# Altium schematic sheet IPC_Controller.SchDoc(IPC_Controller)
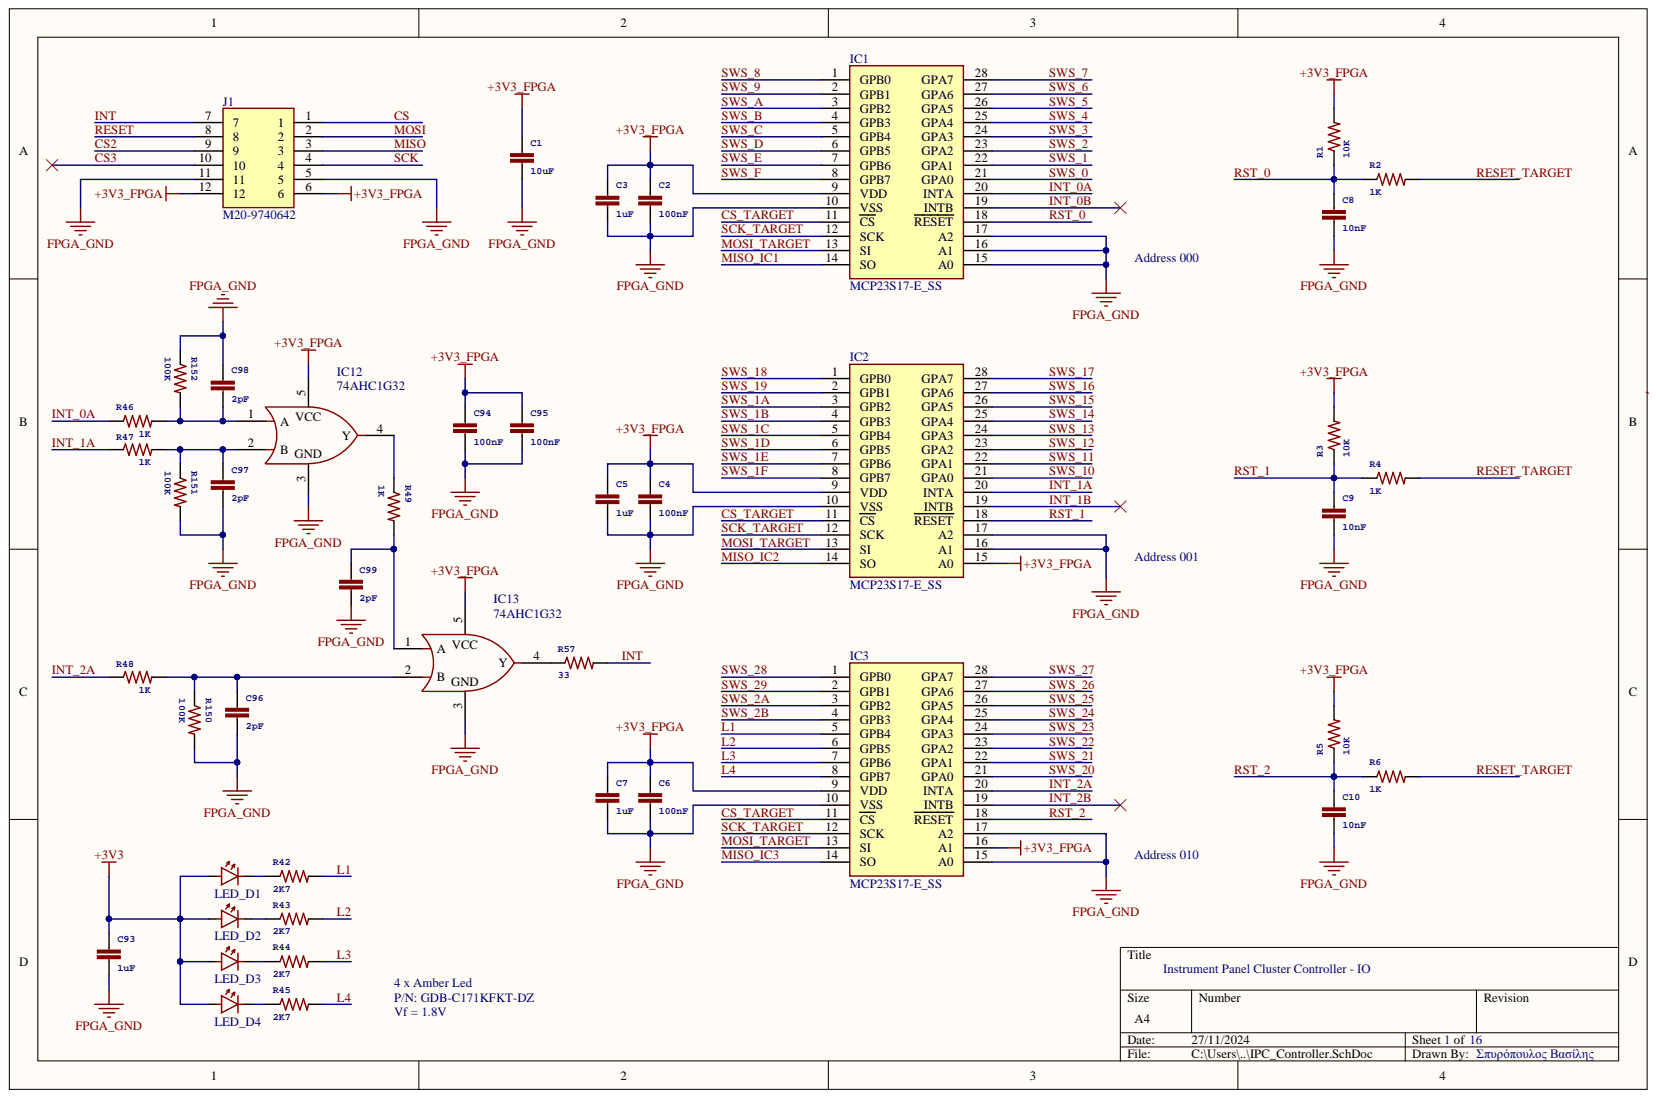
[diagram p0: IPC_Controller.SchDoc]

## Parts
C1  10uF  [C0805]
C2  100nF  [C0603]
C3  1uF  [C0805]
C4  100nF  [C0603]
C5  1uF  [C0805]
C6  100nF  [C0603]
C7  1uF  [C0805]
C8  10nF  [C0603]
C9  10nF  [C0603]
C10  10nF  [C0603]
C93  1uF  [C0805]
C94  100nF  [C0603]
C95  100nF  [C0603]
C96  2pF  [C0603]
C97  2pF  [C0603]
C98  2pF  [C0603]
C99  2pF  [C0603]
IC1  MCP23S17-E_SS  [SOP65P780X200-28N]
IC2  MCP23S17-E_SS  [SOP65P780X200-28N]
IC3  MCP23S17-E_SS  [SOP65P780X200-28N]
IC12  74AHC1G32  [SOT65P212X110-5N]
IC13  74AHC1G32  [SOT65P212X110-5N]
J1  M20-9740642  [M209740642]
LED_D1  LED_0805  [LEDC2012X90N]  (value not drawn)
LED_D2  LED_0805  [LEDC2012X90N]  (value not drawn)
LED_D3  LED_0805  [LEDC2012X90N]  (value not drawn)
LED_D4  LED_0805  [LEDC2012X90N]  (value not drawn)
R1  10K  [R0603]
R2  1K  [R0603]
R3  10K  [R0603]
R4  1K  [R0603]
R5  10K  [R0603]
R6  1K  [R0603]
R42  2K7  [R0603]
R43  2K7  [R0603]
R44  2K7  [R0603]
R45  2K7  [R0603]
R46  1K  [R0603]
R47  1K  [R0603]
R48  1K  [R0603]
R49  1K  [R0603]
R57  33  [R0603]
R150  100K  [R0603]
R151  100K  [R0603]
R152  100K  [R0603]

## Nets
+3V3: C93.1 LED_D1.2 LED_D2.2 LED_D3.2 LED_D4.2
+3V3_FPGA: C1.1 C2.1 C3.1 C4.1 C5.1 C6.1 C7.1 C94.1 C95.1 IC1.9(VDD) IC2.9(VDD) IC2.15(A0) IC3.9(VDD) IC3.16(A1) IC12.5 IC13.5 J1.6 J1.12 R1.2 R3.2 R5.2
FPGA_GND: C1.2 C2.2 C3.2 C4.2 C5.2 C6.2 C7.2 C8.2 C9.2 C10.2 C93.2 C94.2 C95.2 C96.2 C97.2 C98.1 C99.2 IC1.10(VSS) IC1.15(A0) IC1.16(A1) IC1.17(A2) IC2.10(VSS) IC2.16(A1) IC2.17(A2) IC3.10(VSS) IC3.15(A0) IC3.17(A2) IC12.3 IC13.3 J1.5 J1.11 R150.2 R151.2 R152.1
CS: J1.1
CS2: J1.9
CS3: J1.10
CS_TARGET: IC1.11(CS) IC2.11(CS) IC3.11(CS)
INT: J1.7 R57.2
INT_0A: IC1.20(INTA) R46.1
INT_0B: IC1.19(INTB)
INT_1A: IC2.20(INTA) R47.1
INT_1B: IC2.19(INTB)
INT_2A: IC3.20(INTA) R48.1
INT_2B: IC3.19(INTB)
L1: IC3.5(GPB4) R42.2
L2: IC3.6(GPB5) R43.2
L3: IC3.7(GPB6) R44.2
L4: IC3.8(GPB7) R45.2
MISO: J1.3
MISO_IC1: IC1.14(SO)
MISO_IC2: IC2.14(SO)
MISO_IC3: IC3.14(SO)
MOSI: J1.2
MOSI_TARGET: IC1.13(SI) IC2.13(SI) IC3.13(SI)
RESET: J1.8
RESET_TARGET: R2.2 R4.2 R6.2
RST_0: C8.1 IC1.18(RESET) R1.1 R2.1
RST_1: C9.1 IC2.18(RESET) R3.1 R4.1
RST_2: C10.1 IC3.18(RESET) R5.1 R6.1
SCK: J1.4
SCK_TARGET: IC1.12(SCK) IC2.12(SCK) IC3.12(SCK)
SWS_0: IC1.21(GPA0)
SWS_1: IC1.22(GPA1)
SWS_1A: IC2.3(GPB2)
SWS_1B: IC2.4(GPB3)
SWS_1C: IC2.5(GPB4)
SWS_1D: IC2.6(GPB5)
SWS_1E: IC2.7(GPB6)
SWS_1F: IC2.8(GPB7)
SWS_2: IC1.23(GPA2)
SWS_2A: IC3.3(GPB2)
SWS_2B: IC3.4(GPB3)
SWS_3: IC1.24(GPA3)
SWS_4: IC1.25(GPA4)
SWS_5: IC1.26(GPA5)
SWS_6: IC1.27(GPA6)
SWS_7: IC1.28(GPA7)
SWS_8: IC1.1(GPB0)
SWS_9: IC1.2(GPB1)
SWS_10: IC2.21(GPA0)
SWS_11: IC2.22(GPA1)
SWS_12: IC2.23(GPA2)
SWS_13: IC2.24(GPA3)
SWS_14: IC2.25(GPA4)
SWS_15: IC2.26(GPA5)
SWS_16: IC2.27(GPA6)
SWS_17: IC2.28(GPA7)
SWS_18: IC2.1(GPB0)
SWS_19: IC2.2(GPB1)
SWS_20: IC3.21(GPA0)
SWS_21: IC3.22(GPA1)
SWS_22: IC3.23(GPA2)
SWS_23: IC3.24(GPA3)
SWS_24: IC3.25(GPA4)
SWS_25: IC3.26(GPA5)
SWS_26: IC3.27(GPA6)
SWS_27: IC3.28(GPA7)
SWS_28: IC3.1(GPB0)
SWS_29: IC3.2(GPB1)
SWS_A: IC1.3(GPB2)
SWS_B: IC1.4(GPB3)
SWS_C: IC1.5(GPB4)
SWS_D: IC1.6(GPB5)
SWS_E: IC1.7(GPB6)
SWS_F: IC1.8(GPB7)
NetC96_1: C96.1 IC13.2(B) R48.2 R150.1
NetC97_1: C97.1 IC12.2(B) R47.2 R151.1
NetC98_2: C98.2 IC12.1(A) R46.2 R152.2
NetC99_1: C99.1 IC13.1(A) R49.2
NetIC12_4: IC12.4(Y) R49.1
NetIC13_4: IC13.4(Y) R57.1
NetLED_D1_1: LED_D1.1 R42.1
NetLED_D2_1: LED_D2.1 R43.1
NetLED_D3_1: LED_D3.1 R44.1
NetLED_D4_1: LED_D4.1 R45.1

## Library data of the parts
C1 C3 C5 C7 C93 = C-EUC0805: Library Name: SamacSys.SchLib; Component Kind: Standard
C2 C4 C6 C8-C10 C94-C99 = C-EUC0603: Library Name: SamacSys.SchLib; Component Kind: Standard
IC1-IC3 = MCP23S17-E_SS: Integrated Circuit; Manufacturer_Part_Number: MCP23S17-E/SS; Manufacturer_Name: Microchip; Mouser Price/Stock: https://www.mouser.co.uk/ProductDetail/Microchip-Technology-Atmel/MCP23S17-E-SS?qs=usxtMOJb1RweSOLq2FO9lA%3D%3D; Mouser Part Number: 579-MCP23S17-E/SS; Height: 2mm; Datasheet Link: https://datasheet.datasheetarchive.com/originals/distributors/SFDatasheet-4/sf-00090057.pdf; Component Kind: Standard; Arrow Price/Stock: https://www.arrow.com/en/products/mcp23s17-ess/microchip-technology?region=nac; Arrow Part Number: MCP23S17-E/SS
IC12 IC13 = 74AHC1G32GW,125: Integrated Circuit; Manufacturer_Name: Nexperia; Mouser Price/Stock: https://www.mouser.co.uk/ProductDetail/Nexperia/74AHC1G32GW125?qs=P62ublwmbi8QXd1KZvsZnA%3D%3D; Mouser Part Number: 771-74AHC1G32GW-G; Manufacturer_Part_Number: 74AHC1G32GW,125; Library Name: SamacSys.SchLib; Height: 1.1mm; Datasheet Link: https://assets.nexperia.com/documents/data-sheet/74AHC_AHCT1G32.pdf; Component Kind: Standard; Arrow Price/Stock: https://www.arrow.com/en/products/74ahc1g32gw125/nexperia?region=nac; Arrow Part Number: 74AHC1G32GW,125
J1 = M20-9740642: Connector; Manufacturer_Name: HARWIN; Mouser Price/Stock: https://www.mouser.com/Search/Refine.aspx?Keyword=855-M20-9740642; Mouser Part Number: 855-M20-9740642; Manufacturer_Part_Number: M20-9740642; Library Name: SamacSys.SchLib; Height: 5.28mm; Datasheet Link: https://componentsearchengine.com/Datasheets/1/M20-9740642.pdf; Component Kind: Standard
LED_D1-LED_D4 = LED_0805: LED; Manufacturer_Part_Number: LTST-C171GKT; Manufacturer_Name: Lite-On; Mouser Price/Stock: https://www.mouser.com/Search/Refine.aspx?Keyword=859-LTST-C171GKT; Mouser Part Number: 859-LTST-C171GKT; Library Name: SamacSys.SchLib; Height: 0.9mm; Datasheet Link: https://componentsearchengine.com/Datasheets/1/LTST-C171GKT.pdf; Component Kind: Standard
R1-R6 R42-R49 R57 R150-R152 = R-US_R0603: Library Name: SamacSys.SchLib; Component Kind: Standard
